# Altium schematic sheet eBox_3G_REG.schdoc
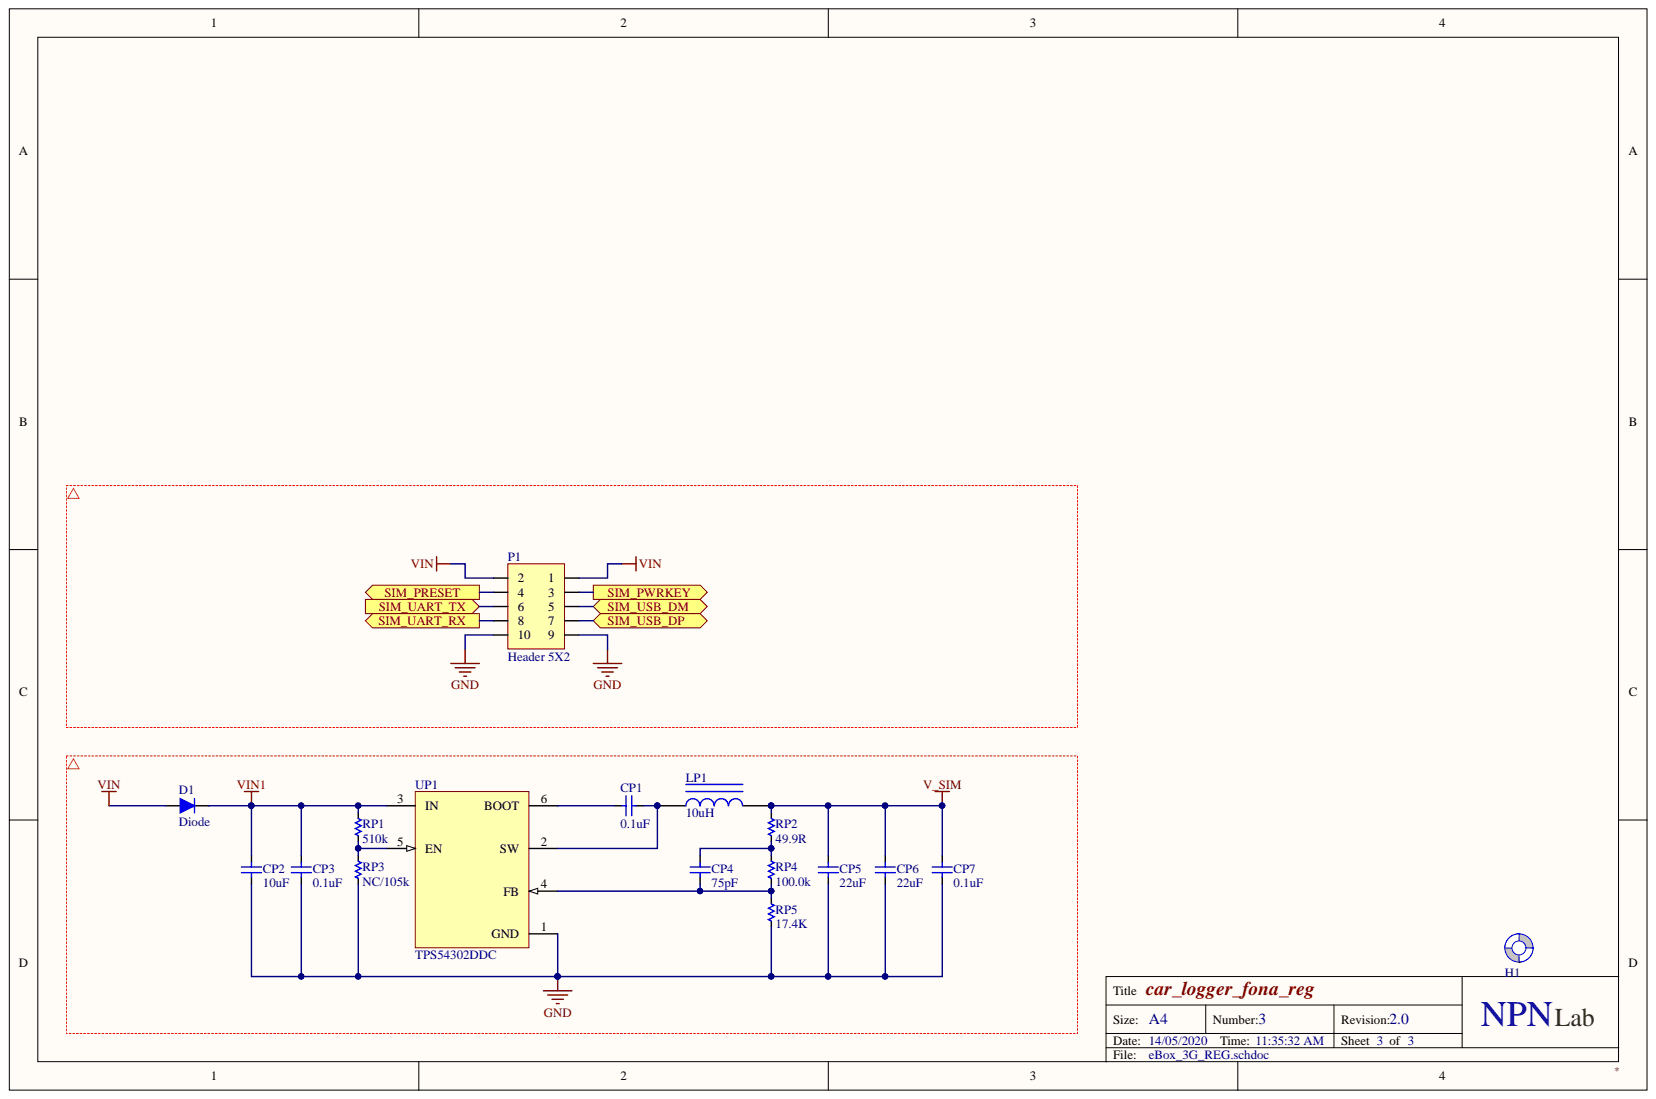
[diagram p0: eBox_3G_REG.schdoc]

## Parts
CP1  0.1uF  [CC 0603]
CP2  10uF  [CC 0805]
CP3  0.1uF  [CC 0603]
CP4  75pF  [CC 0603]
CP5  22uF  [CC 0805]
CP6  22uF  [CC 0805]
CP7  0.1uF  [CC 0603]
D1  Diode  [DO-214AC (SMA) L]
H1  Screw Hole  [SCREW/2.8M/5M]  (value not drawn)  (no BOM)
LP1  10uH  [IND_MWSA0518_525418]
P1  Header 5X2  [HDR/Header/10/DL]
RP1  510k  [RR 0603]
RP2  49.9R  [RR 0603]
RP3  NC/105k  [RR 0603]
RP4  100.0k  [RR 0603]
RP5  17.4K  [RR 0603]
UP1  TPS54302DDC  [SOT-23-6]

## Ports
SIM_PRESET, SIM_PWRKEY, SIM_UART_RX, SIM_UART_TX, SIM_USB_DM, SIM_USB_DP

## Nets
GND: CP2.2 CP3.2 CP5.2 CP6.2 CP7.2 P1.9 P1.10 RP3.1 RP5.2 UP1.1(GND)
V_SIM: CP5.1 CP6.1 CP7.1 LP1.2 RP2.1
VIN: D1.1 P1.1 P1.2
VIN1: CP2.1 CP3.1 D1.2 RP1.2 UP1.3(IN)
SIM_PRESET: P1.4 ; port SIM_PRESET
SIM_PWRKEY: P1.3 ; port SIM_PWRKEY
SIM_UART_RX: P1.8 ; port SIM_UART_RX
SIM_UART_TX: P1.6 ; port SIM_UART_TX
SIM_USB_DM: P1.5 ; port SIM_USB_DM
SIM_USB_DP: P1.7 ; port SIM_USB_DP
NetCP1_1: CP1.1 UP1.6(BOOT)
NetCP1_2: CP1.2 LP1.1 UP1.2(SW)
NetCP4_1: CP4.1 RP2.2 RP4.1
NetCP4_2: CP4.2 RP4.2 RP5.1 UP1.4(FB)
NetRP1_1: RP1.1 RP3.2 UP1.5(EN)

Not connected: H1 1

## Library data of the parts
CP1 CP3 CP7 = Capacitor NP: CAP CER 0.1UF 16V X7R 0603; Manufacturer: Murata Electronics; Manufacturer Part Number: GCJ188R71C104KA01D; Component Kind: Standard; Library Name: car_logger_libs.IntLib; Package: 0603 (1608 Metric); Supplier 1: Digi-Key; Supplier Part Number 1: 490-5796-2-ND; Termination Style: SMD/SMT
CP2 = Capacitor NP: CAP CER 10UF 25V X5R 0805; Manufacturer: Murata Electronics; Manufacturer Part Number: GRM21BR61E106MA73L; Component Kind: Standard; Library Name: car_logger_libs.IntLib; Package: 0805 (2012 Metric); Supplier 1: Digi-Key; Supplier Part Number 1: 490-10748-2-ND; Termination Style: SMD/SMT
CP4 = Capacitor NP: CAP CER 75PF 50V C0G/NP0 0603; Manufacturer: Murata Electronics; Manufacturer Part Number: GRM1885C1H750JA01D; Component Kind: Standard; Library Name: car_logger_libs.IntLib; Package: 0603 (1608 Metric); Supplier 1: Digi-Key; Supplier Part Number 1: 490-1424-1-ND; Termination Style: SMD/SMT
CP5 CP6 = Capacitor NP: CAP CER 22UF 25V X5R 0805; Manufacturer: Murata Electronics; Manufacturer Part Number: GRM21BR61E226ME44L; Component Kind: Standard; Library Name: car_logger_libs.IntLib; Package: 0805 (2012 Metric); Supplier 1: Digi-Key; Supplier Part Number 1: 490-10749-2-ND; Termination Style: SMD/SMT
D1 = Diode: Default Diode; Component Kind: Standard; Library Name: chipfc_altium_libs.IntLib
LP1 = Inductor Power: Fixed Inductors 10uH 20% Low Profile; Manufacturer: Sunlord; Manufacturer Part Number: MWSA0518-100MT; Component Kind: Standard; Library Name: car_logger_libs.IntLib; Package: 5254; Termination Style: SMD/SMT
P1 = Header 5X2: Header, 5-Pin, Dual row; Component Kind: Standard; Library Name: chipfc_altium_libs.IntLib
RP1 = Resistors: RES SMD 510K OHM 1% 1/10W 0603; Manufacturer: Panasonic Industrial Devices; Manufacturer Part Number: ERJ-3EKF5103V; Component Kind: Standard; Library Name: car_logger_libs.SchLib; Package: 0603 (1608 Metric); Supplier 1: Digi-Key; Supplier Part Number 1: P510KHCT-ND; Termination Style: SMD/SMT
RP2 = Resistors: RES SMD 49.9 OHM 1% 1/10W 0603; Manufacturer: Panasonic Industrial Devices; Manufacturer Part Number: ERJ-3EKF49R9V; Component Kind: Standard; Library Name: car_logger_libs.SchLib; Package: 0603 (1608 Metric); Supplier 1: Digi-Key; Supplier Part Number 1: P49.9HTR-ND; Termination Style: SMD/SMT
RP3 = Resistors: RES SMD 105K OHM 1% 1/10W 0603; Manufacturer: Panasonic Industrial Devices; Manufacturer Part Number: ERJ-3EKF1053V; Component Kind: Standard; Library Name: car_logger_libs.SchLib; Package: 0603 (1608 Metric); Supplier 1: Digi-Key; Supplier Part Number 1: P105KHCT-ND; Termination Style: SMD/SMT
RP4 = Resistors: RES SMD 100K OHM 1% 1/10W 0603; Manufacturer: Panasonic Industrial Devices; Manufacturer Part Number: ERJ-3EKF1003V; Component Kind: Standard; Library Name: car_logger_libs.SchLib; Package: 0603 (1608 Metric); Supplier 1: Digi-Key; Supplier Part Number 1: P100KHCT-ND; Termination Style: SMD/SMT
RP5 = Resistors: RES SMD 17.4K OHM 1% 1/10W 0603; Manufacturer: Panasonic Industrial Devices; Manufacturer Part Number: ERJ-3EKF1742V; Component Kind: Standard; Library Name: car_logger_libs.SchLib; Package: 0603 (1608 Metric); Supplier 1: Digi-Key; Supplier Part Number 1: P17.4KHTR-ND; Termination Style: SMD/SMT
UP1 = TPS56339: IC REG BUCK ADJ 3A TSOT23-6; Manufacturer: Texas Instruments; Manufacturer Part Number: TPS54302DDCR; Component Kind: Standard; Library Name: car_logger_libs.SchLib; Package: SOT-23-6; Supplier 1: Digi-Key; Supplier Part Number 1: 296-46237-1-ND; Termination Style: SMD/SMT
H1 = Screw Hole: Description: Screw Hole; Library Name: chipfc_altium_libs_extras.IntLib
